# Altium schematic sheet 11.CAN.SchDoc(11.CAN)
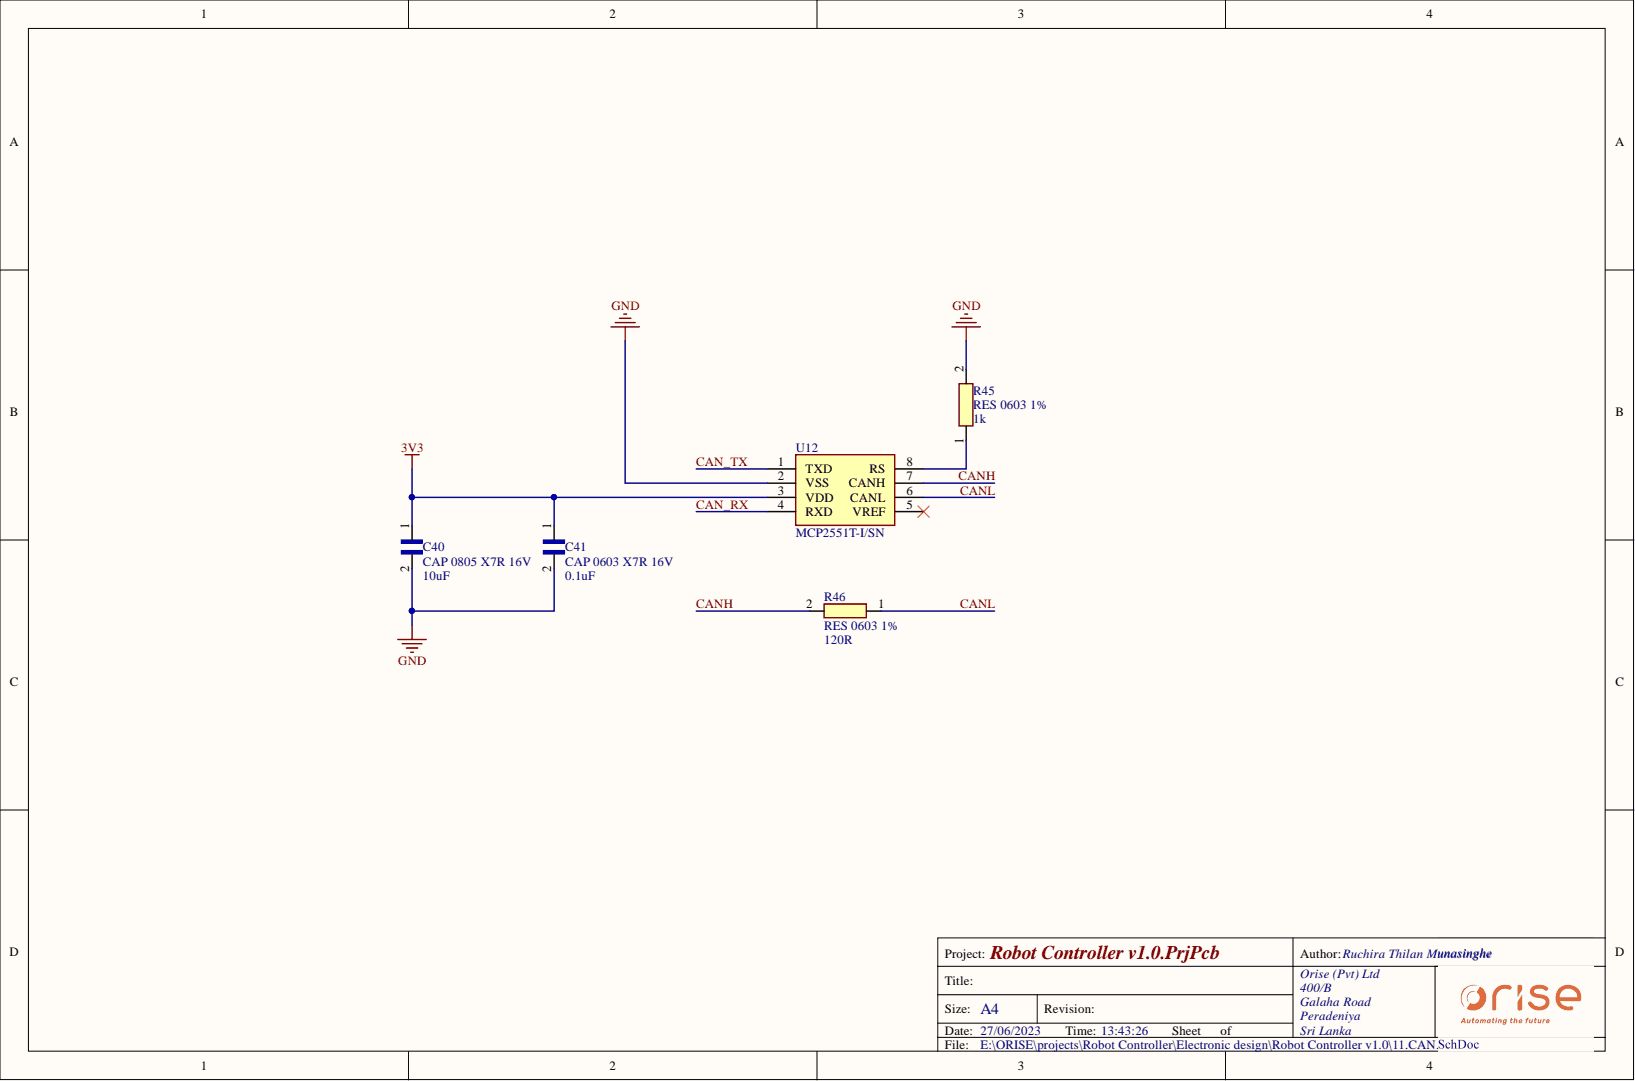
[diagram p0: 11.CAN.SchDoc]

## Parts
C40  CAP 0805 X7R 16V  value 10uF  [CAP 0805]
C41  CAP 0603 X7R 16V  value 0.1uF  [CAP 0603]
R45  RES 0603 1%  value 1k  [RES 0603]
R46  RES 0603 1%  value 120R  [RES 0603]
U12  MCP2551T-I/SN  [MCP2551T]

## Nets
3V3: C40.1 C41.1 U12.3(VDD)
GND: C40.2 C41.2 R45.2 U12.2(VSS)
CAN_RX: U12.4(RXD)
CAN_TX: U12.1(TXD)
CANH: R46.2 U12.7(CANH)
CANL: R46.1 U12.6(CANL)
NetR45_1: R45.1 U12.8(RS)

Not connected: U12 5(VREF)

## Library data of the parts
U12 = MCP2551T-I/SN: 1/1 1Mbps CAN Transceiver SOIC-8_150mil CAN ICs ROHS; Manufacturer_Part_Number: C49558; Manufacturer_Name: Microchip; Library Name: Robot Controller v1.0.SchLib; LCSC Part Number: 579-MCP2551T-I/SN; Component Kind: Standard
C40 = CAP 0805 X7R 16V: Library Name: Robot Controller v1.0.SchLib; Description: CAP 0805 X7R 16V; Component Kind: Standard
C41 = CAP 0603 X7R 16V: Library Name: Robot Controller v1.0.SchLib; Description: CAP 0603 X7R 16V; Component Kind: Standard
R45 R46 = RES 0603 1%: Library Name: Robot Controller v1.0.SchLib; Description: RES 0603 1%; Component Kind: Standard
